# Altium schematic sheet Badge2024.SchDoc(Badge2024)
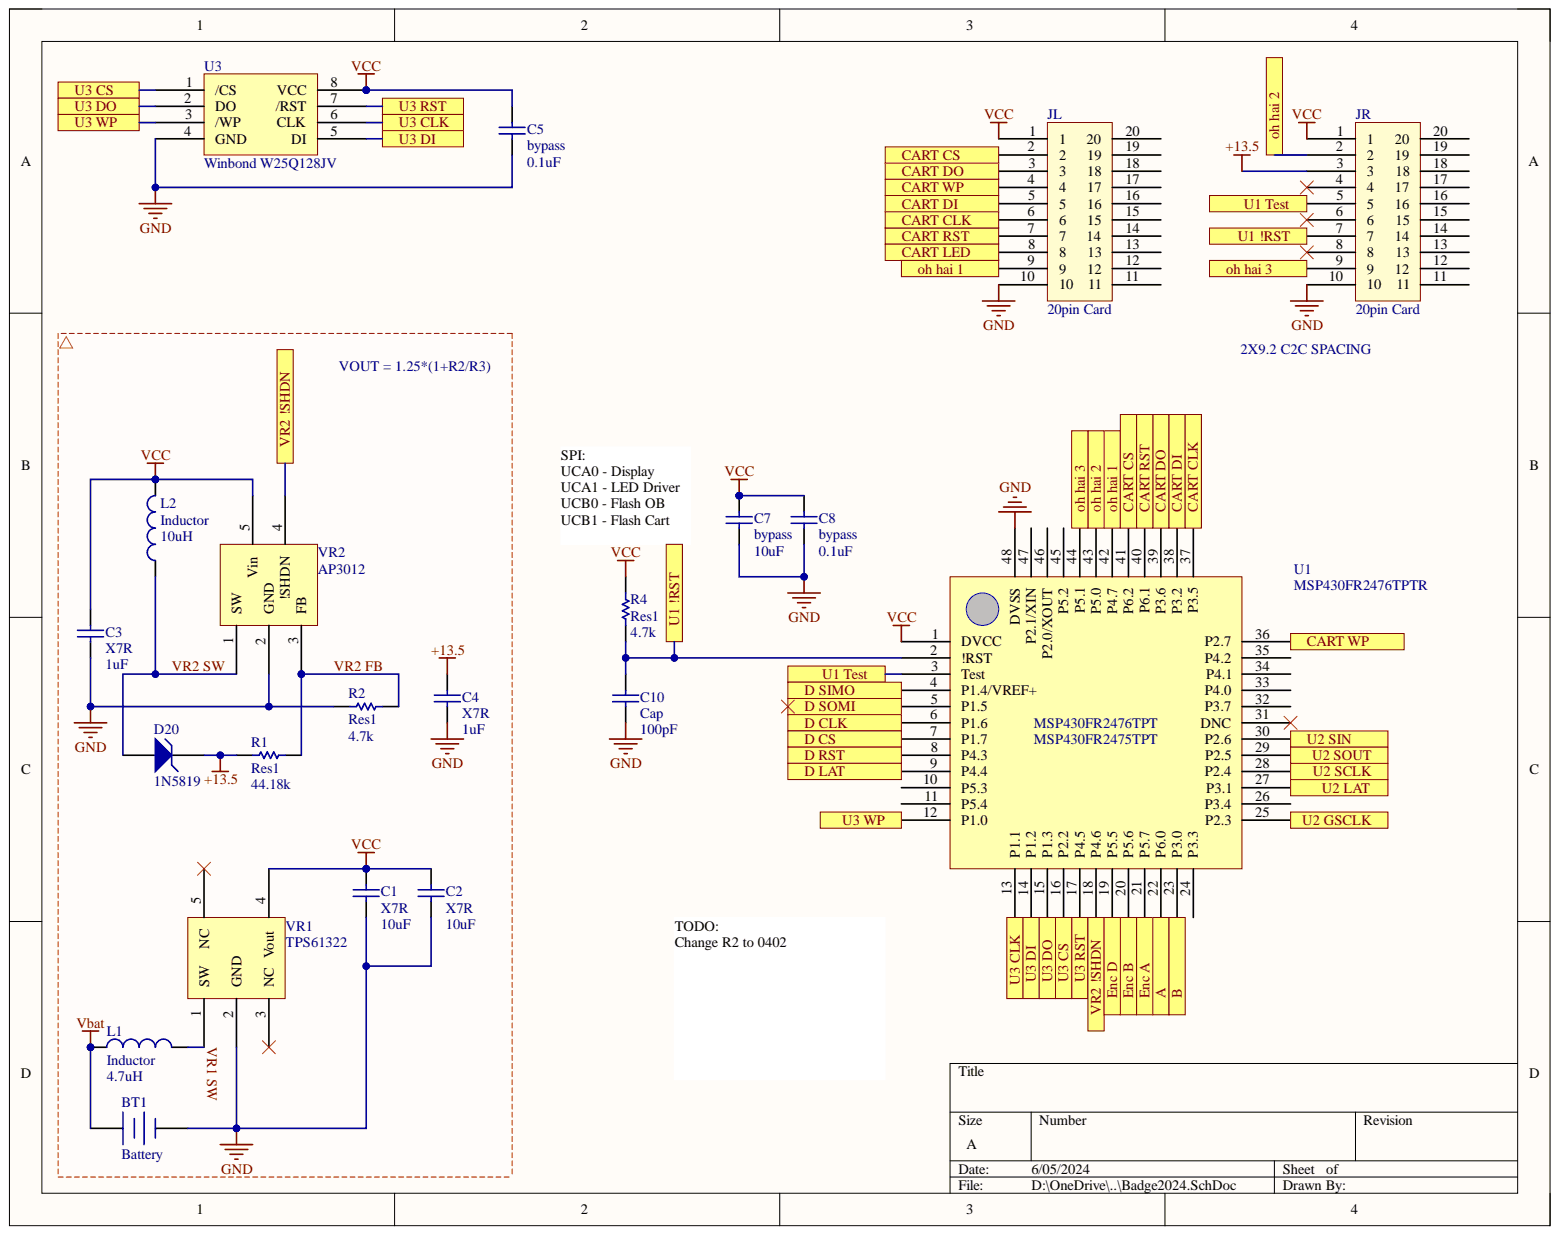
[diagram p0: Badge2024.SchDoc]

## Parts
BT1  Battery  [BATT2AA]
C1  X7R  value 10uF  [CAPC0402]
C2  X7R  value 10uF  [CAPC0402]
C3  X7R  value 1uF  [CAPC1608(0603)]
C4  X7R  value 1uF  [CAPC1608(0603)]
C5  bypass  value 0.1uF  [CAPC0402]
C7  bypass  value 10uF  [CAPC0402]
C8  bypass  value 0.1uF  [CAPC0402]
C10  Cap  value 100pF  [CAPC0402]
D20  1N5819  [SODFL405X135-2N]
JL  20pin Card  [Amphenol 20pin Card]
JR  20pin Card  [Amphenol 20pin Card]
L1  Inductor  value 4.7uH  [INDC2520X12N]
L2  Inductor  value 10uH  [INDP3838X15N]
R1  Res1  value 44.18k  [RESC1608(0603)]
R2  Res1  value 4.7k  [RESC1608(0603)]
R4  Res1  value 4.7k  [RESC1105(0402)]
U1  MSP430FR2476TPTR  [TSQFP50P900X900X160-48L]
U3  Winbond W25Q128JV  [SOIC127P790X175-8L]
VR1  TPS61322  [SOT95P280X110-5N]
VR2  AP3012  [SOT95P280X145-5N]

## Ports
A, B, CART CLK, CART CS, CART DI, CART DO, CART LED, CART RST, CART WP, D CLK, D CS, D LAT, D RST, D SIMO, D SOMI, Enc A, Enc B, Enc D, oh hai 1, oh hai 2, oh hai 3, U1 !RST, U1 Test, U2 GSCLK, U2 LAT, U2 SCLK, U2 SIN, U2 SOUT, U3 CLK, U3 CS, U3 DI, U3 DO, U3 RST, U3 WP, VR2 !SHDN

## Nets
+13.5: C4.2 D20.2 JR.3 R1.2
GND: BT1.2 C1.1 C2.1 C3.1 C4.1 C5.1 C7.1 C8.1 C10.1 JL.10 JR.10 R2.1 U1.48(DVSS) U3.4(GND) VR1.2(GND) VR2.2(GND)
Vbat: BT1.1 L1.1
VCC: C1.2 C2.2 C3.2 C5.2 C7.2 C8.2 JL.1 JR.1 L2.2 R4.2 U1.1(DVCC) U3.8(VCC) VR1.4(Vout) VR2.5(Vin)
A: U1.22(P6.0) ; port A
B: U1.23(P3.0) ; port B
CART CLK: JL.6 U1.37(P3.5) ; port CART CLK
CART CS: JL.2 U1.41(P6.2) ; port CART CS
CART DI: JL.5 U1.38(P3.2) ; port CART DI
CART DO: JL.3 U1.39(P3.6) ; port CART DO
CART LED: JL.8 ; port CART LED
CART RST: JL.7 U1.40(P6.1) ; port CART RST
CART WP: JL.4 U1.36(P2.7) ; port CART WP
D CLK: U1.6(P1.6) ; port D CLK
D CS: U1.7(P1.7) ; port D CS
D LAT: U1.9(P4.4) ; port D LAT
D RST: U1.8(P4.3) ; port D RST
D SIMO: U1.4(P1.4/VREF+) ; port D SIMO
D SOMI: U1.5(P1.5) ; port D SOMI
Enc A: U1.21(P5.7) ; port Enc A
Enc B: U1.20(P5.6) ; port Enc B
Enc D: U1.19(P5.5) ; port Enc D
oh hai 1: JL.9 U1.42(P4.7) ; port oh hai 1
oh hai 2: JR.2 U1.43(P5.0) ; port oh hai 2
oh hai 3: JR.9 U1.44(P5.1) ; port oh hai 3
U1 !RST: C10.2 JR.7 R4.1 U1.2(!RST) ; port U1 !RST
U1 Test: JR.5 U1.3(Test) ; port U1 Test
U2 GSCLK: U1.25(P2.3) ; port U2 GSCLK
U2 LAT: U1.27(P3.1) ; port U2 LAT
U2 SCLK: U1.28(P2.4) ; port U2 SCLK
U2 SIN: U1.30(P2.6) ; port U2 SIN
U2 SOUT: U1.29(P2.5) ; port U2 SOUT
U3 CLK: U1.13(P1.1) U3.6(CLK) ; port U3 CLK
U3 CS: U1.16(P2.2) U3.1(/CS) ; port U3 CS
U3 DI: U1.14(P1.2) U3.5(DI) ; port U3 DI
U3 DO: U1.15(P1.3) U3.2(DO) ; port U3 DO
U3 RST: U1.17(P4.5) U3.7(/RST) ; port U3 RST
U3 WP: U1.12(P1.0) U3.3(/WP) ; port U3 WP
VR1 SW: L1.2 VR1.1(SW)
VR2 !SHDN: U1.18(P4.6) VR2.4(!SHDN) ; port VR2 !SHDN
VR2 FB: R1.1 R2.2 VR2.3(FB)
VR2 SW: D20.1 L2.1 VR2.1(SW)

Not connected: JL 11 12 13 14 15 16 17 18 19 20; JR 4 6 8 11 12 13 14 15 16 17 18 19 20; U1 10(P5.3) 11(P5.4) 24(P3.3) 26(P3.4) 31(DNC) 32(P3.7) 33(P4.0) 34(P4.1) 35(P4.2) 45(P5.2) 46(P2.0/XOUT) 47(P2.1/XIN); VR1 3(NC) 5(NC)

## Library data of the parts
BT1 = Battery: Multicell Battery; Component Kind: Standard; LatestRevisionDate: 17-Jul-2002; LatestRevisionNote: Re-released for DXP Platform.; Library Name: Miscellaneous Devices.IntLib; PackageDescription: Battery; 2 Leads; PackageReference: BAT-2; Published: 24-Mar-1999; Publisher: Altium Limited
C1-C5 C7 C8 C10 = Cap: Capacitor; Component Kind: Standard; LatestRevisionDate: 17-Jul-2002; LatestRevisionNote: Re-released for DXP Platform.; Library Name: Miscellaneous Devices.IntLib; PackageDescription: Radial Cap, Thru-Hole; 2 Leads; 0.3 in Pin Spacing; PackageReference: RAD-0.3; Published: 8-Jun-2000; Signal Integrity: Cap; Simulation: CAP; Publisher: Altium Limited
D20 = D Zener: Zener Diode; Component Kind: Standard; LatestRevisionDate: 17-Jul-2002; LatestRevisionNote: Re-released for DXP Platform.; Library Name: Miscellaneous Devices.IntLib; PackageDescription: Diode, Thru-Hole; 2 Leads; 0.7 in Pin Spacing; PackageReference: DIODE-0.7; Published: 8-Jun-2000; Signal Integrity: D Zener; Simulation: ZENER; Publisher: Altium Limited
L1 = Inductor: Code_IEC: 1005; Value: 4.7uH; Code_JEITA: 0402; Component Kind: Standard; Description: Inductor; LatestRevisionDate: 29-May-2009; LatestRevisionNote: IPC-7351 Footprint Added.; Library Name: Miscellaneous Devices.IntLib; PackageDescription: Chip Inductor; PackageReference: 0402-A; Published: 8-Jun-2000; Signal Integrity: Inductor; Simulation: INDUCTOR; Publisher: Altium Limited
L2 = Inductor: Code_IEC: 1005; Code_JEITA: 0402; Component Kind: Standard; Description: Inductor; LatestRevisionDate: 29-May-2009; LatestRevisionNote: IPC-7351 Footprint Added.; Library Name: Miscellaneous Devices.IntLib; PackageDescription: Chip Inductor; PackageReference: 0402-A; Published: 8-Jun-2000; Signal Integrity: Inductor; Simulation: INDUCTOR; Publisher: Altium Limited
R1 R2 R4 = Res1: Resistor; Component Kind: Standard; LatestRevisionDate: 17-Jul-2002; LatestRevisionNote: Re-released for DXP Platform.; Library Name: Miscellaneous Devices.IntLib; PackageDescription: Axial Device, Thru-Hole; 2 Leads; 0.3 in Pin Spacing; PackageReference: AXIAL-0.3; Published: 8-Jun-2000; Signal Integrity: Res; Simulation: RESISTOR; Publisher: Altium Limited
JL JR = 20pin Card: Component Kind: Standard; Library Name: 2024.SchLib
U1 = MSP430FR2476TPTR: Component Kind: Standard; Library Name: 2024.SchLib
U3 = Winbond W25Q128JV: Component Kind: Standard; Library Name: 2024.SchLib
VR1 = TPS61322: Component Kind: Standard; Library Name: 2024.SchLib
VR2 = AP3012: Component Kind: Standard; Library Name: 2024.SchLib
